# Altium schematic sheet Goouuu_GPRSV2.SchDoc
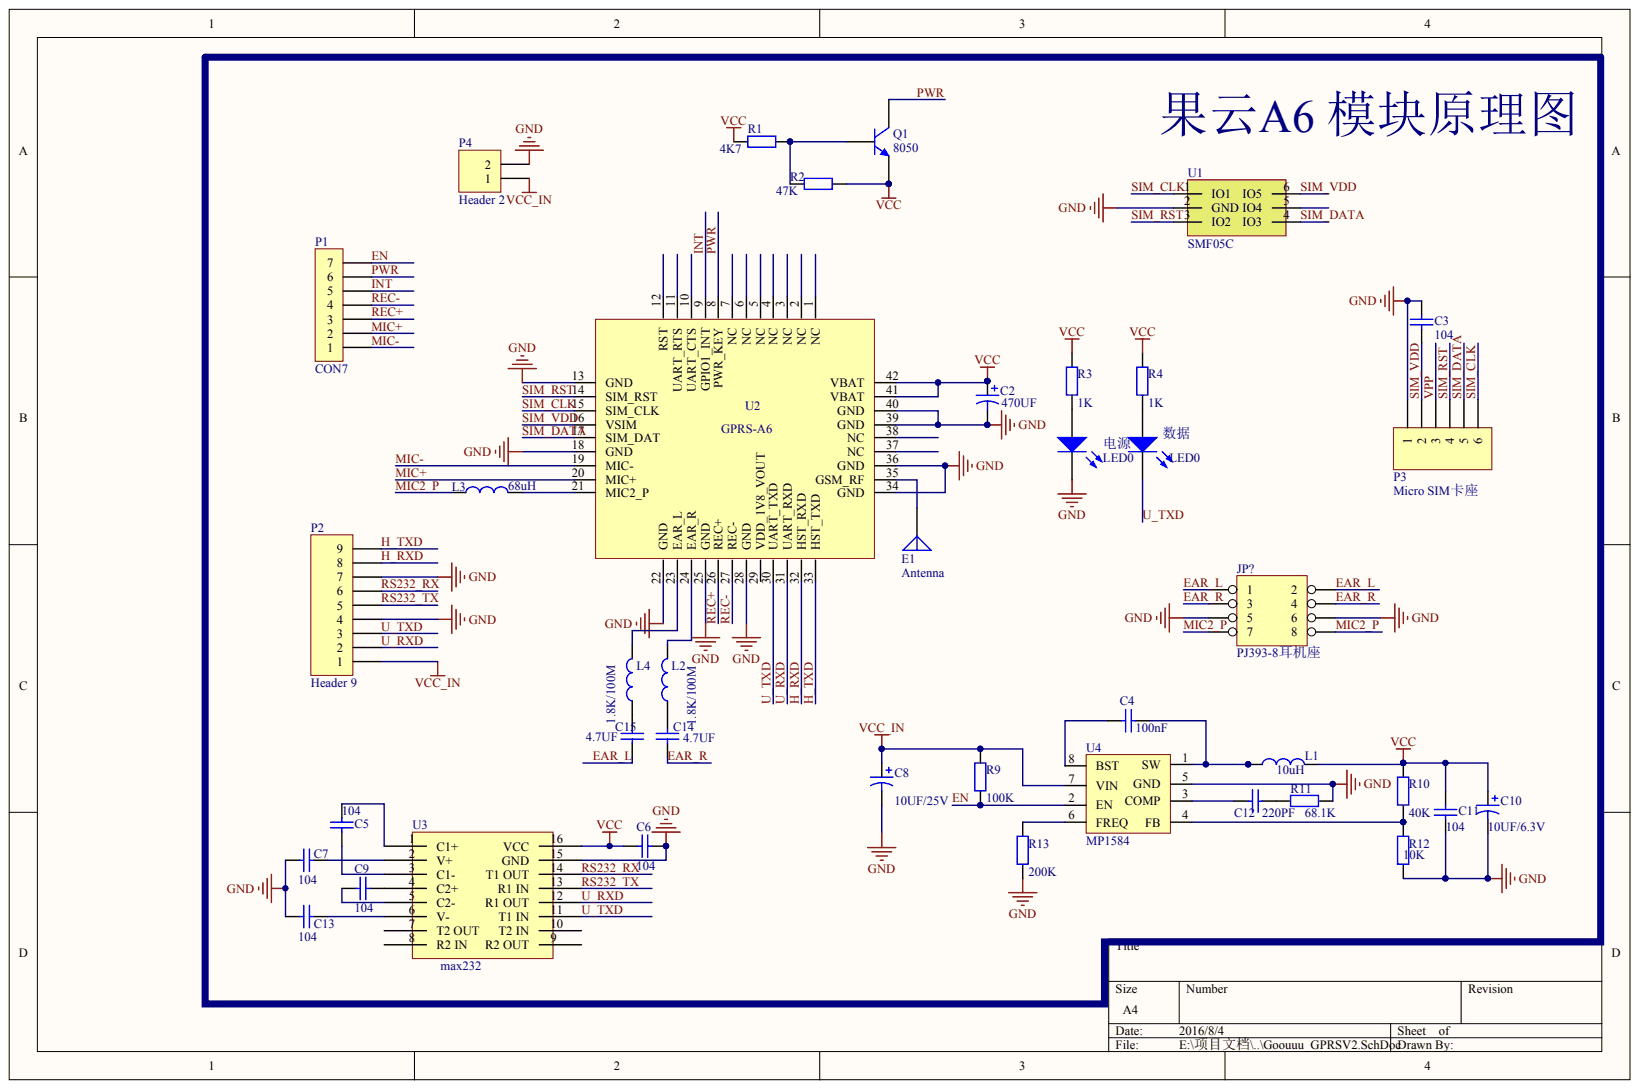
[diagram p0: Goouuu_GPRSV2.SchDoc]

## Parts
C2  value 470UF
C3  value 104  (hidden comment: Cap)
C4  value 100nF
C5  104
C6  104
C7  104
C8  value 10UF/25V
C9  104
C10  value 10UF/6.3V
C11  value 104
C12  value 220PF
C13  104
C14  value 4.7UF  (hidden comment: Cap)
C15  value 4.7UF  (hidden comment: Cap)
E1  Antenna
JP?  PJ393-8耳机座
L1  10uH
L2  1.8K/100M
L3  68uH
L4  1.8K/100M
P1  CON7
P2  Header 9
P3  Micro SIM卡座
P4  Header 2
Q1  8050
R1  value 4K7
R2  value 47K
R3  value 1K
R4  value 1K
R9  value 100K
R10  value 40K
R11  value 68.1K
R12  value 10K
R13  value 200K
U1  SMF05C
U2  (no value)
U3  max232
U4  MP1584
数据  LED0
电源  LED0

## Nets
GND: C2.2 C3.1 C6.1 C7.2 C8.2 C10.2 C11.2 C13.2 JP?.5 JP?.6 P2.4 P2.7 P3.1 P4.2 R11.1 R12.2 R13.1 U1.2(GND) U2.13(GND) U2.18(GND) U2.22(GND) U2.25(GND) U2.28(GND) U2.34(GND) U2.36(GND) U2.39(GND) U2.40(GND) U3.15(GND) U4.5(GND) 电源.2
VCC: C2.1 C6.2 C10.1 C11.1 L1.1 Q1.1 R1.2 R2.1 R3.2 R4.2 R10.1 U2.41(VBAT) U2.42(VBAT) U3.16(VCC)
VCC_IN: C8.1 P2.1 P4.1 R9.2 U4.7(VIN)
EAR_L: C15.2 JP?.1 JP?.2
EAR_R: C14.2 JP?.3 JP?.4
EN: P1.7 R9.1 U4.2(EN)
H_RXD: P2.8 U2.32(HST_RXD)
H_TXD: P2.9 U2.33(HST_TXD)
INT: P1.5 U2.9(GPIO1_INT)
MIC2_P: JP?.7 JP?.8 L3.2
MIC+: P1.2 U2.20(MIC+)
MIC-: P1.1 U2.19(MIC-)
PWR: P1.6 Q1.3 U2.8(PWR_KEY)
REC+: P1.3 U2.26(REC+)
REC-: P1.4 U2.27(REC-)
RS232_RX: P2.6 U3.14(T1_OUT)
RS232_TX: P2.5 U3.13(R1_IN)
SIM_CLK: P3.6 U1.1(IO1) U2.15(SIM_CLK)
SIM_DATA: P3.5 U1.4(IO3) U2.17(SIM_DAT)
SIM_RST: P3.4 U1.3(IO2) U2.14(SIM_RST)
SIM_VDD: C3.2 P3.2 U1.6(IO5) U2.16(VSIM)
U_RXD: P2.2 U2.31(UART_RXD) U3.12(R1_OUT)
U_TXD: P2.3 U2.30(UART_TXD) U3.11(T1_IN) 数据.2
VPP: P3.3
NetC4_1: C4.1 L1.2 U4.1(SW)
NetC4_2: C4.2 U4.8(BST)
NetC5_1: C5.1 U3.3(C1-)
NetC5_2: C5.2 U3.1(C1+)
NetC7_1: C7.1 U3.2(V+)
NetC9_1: C9.1 U3.4(C2+)
NetC9_2: C9.2 U3.5(C2-)
NetC12_1: C12.1 R11.2
NetC12_2: C12.2 U4.3(COMP)
NetC13_1: C13.1 U3.6(V-)
NetC14_1: C14.1 L2.2
NetC15_1: C15.1 L4.2
NetE1_1: E1.1 U2.35(GSM_RF)
NetL2_1: L2.1 U2.24(EAR_R)
NetL3_1: L3.1 U2.21(MIC2_P)
NetL4_1: L4.1 U2.23(EAR_L)
NetQ1_2: Q1.2 R1.1 R2.2
NetR3_1: R3.1 电源.1
NetR4_1: R4.1 数据.1
NetR10_2: R10.2 R12.1 U4.4(FB)
NetR13_2: R13.2 U4.6(FREQ)
N00011:

Not connected: U1 5(IO4); U2 1(NC) 2(NC) 3(NC) 4(NC) 5(NC) 6(NC) 7(NC) 10(UART_CTS) 11(UART_RTS) 12(RST) 29(VDD_1V8_VOUT) 37(NC) 38(NC); U3 7(T2_OUT) 8(R2_IN) 9(R2_OUT) 10(T2_IN)

## Library data of the parts
C5-C7 C9 C13 = (no symbol name): Value: 100pF; Published: 8-Jun-2000; LatestRevisionDate: 17-Jul-2002; LatestRevisionNote: Re-released for DXP Platform.; PackageReference: RAD-0.3; PackageDescription: Radial Cap, Thru-Hole; 2 Leads; 0.3 in Pin Spacing; Publisher: Altium Limited
C2-C4 C8 C10-C12 C14 C15 E1 JP? L1-L4 P1-P4 Q1 R1-R4 R9-R13 U1-U4 数据 电源 = (no symbol name)
  C2 C8 C10: Published: 8-Jun-2000; LatestRevisionDate: 17-Jul-2002; LatestRevisionNote: Re-released for DXP Platform.; PackageReference: RB7.6-15; PackageDescription: Polarized Capacitor (Radial); 2 Leads
  C3 C4 C11 C12 C14 C15: Published: 8-Jun-2000; LatestRevisionDate: 17-Jul-2002; LatestRevisionNote: Re-released for DXP Platform.; PackageReference: RAD-0.3; PackageDescription: Radial Cap, Thru-Hole; 2 Leads; 0.3 in Pin Spacing
  E1: Published: 24-Mar-1999; LatestRevisionDate: 17-Jul-2002; LatestRevisionNote: Re-released for DXP Platform.; PackageReference: PIN1
  P2-P4: LatestRevisionDate: 17-Jul-2002; LatestRevisionNote: Re-released for DXP Platform.
  Q1: Published: 8-Jun-2000; LatestRevisionDate: 15-Jan-2003; LatestRevisionNote: PCB Footprint 'BCY-W3/D4.7' replaced; DatasheetVersion: 1997; PackageVersion: Sep-1998; PackageReference: TO-92A; PackageDescription: TO, Flat Index; 3 In-Line, Axial Leads; Body Dia. 4.5mm; Leads 0.51 x 0.48 mm (max); ComponentLink1Description: Manufacturer Link; ComponentLink1URL: http://www.fairchildsemi.com/; ComponentLink2Description: Datasheet; ComponentLink2URL: http://www.fairchildsemi.com/ds/2N%2F2N3904.pdf
  R1-R4 R9-R13: Published: 8-Jun-2000; LatestRevisionDate: 17-Jul-2002; LatestRevisionNote: Re-released for DXP Platform.; PackageReference: AXIAL-0.4; PackageDescription: Axial Device, Thru-Hole; 2 Leads; 0.4 in Pin Spacing
  数据 电源: Published: 8-Jun-2000; LatestRevisionDate: 08-Jul-2005; LatestRevisionNote: Mech Layer 1 removed.; PackageReference: LED-0; PackageDescription: LED; 2 Leads
  C2-C4 C8 C10-C12 C14 C15 E1 P2-P4 Q1 R1-R4 R9-R13 数据 电源: Publisher: Altium Limited
